# Altium schematic sheet MA_Interface,RevA,01.SchDoc
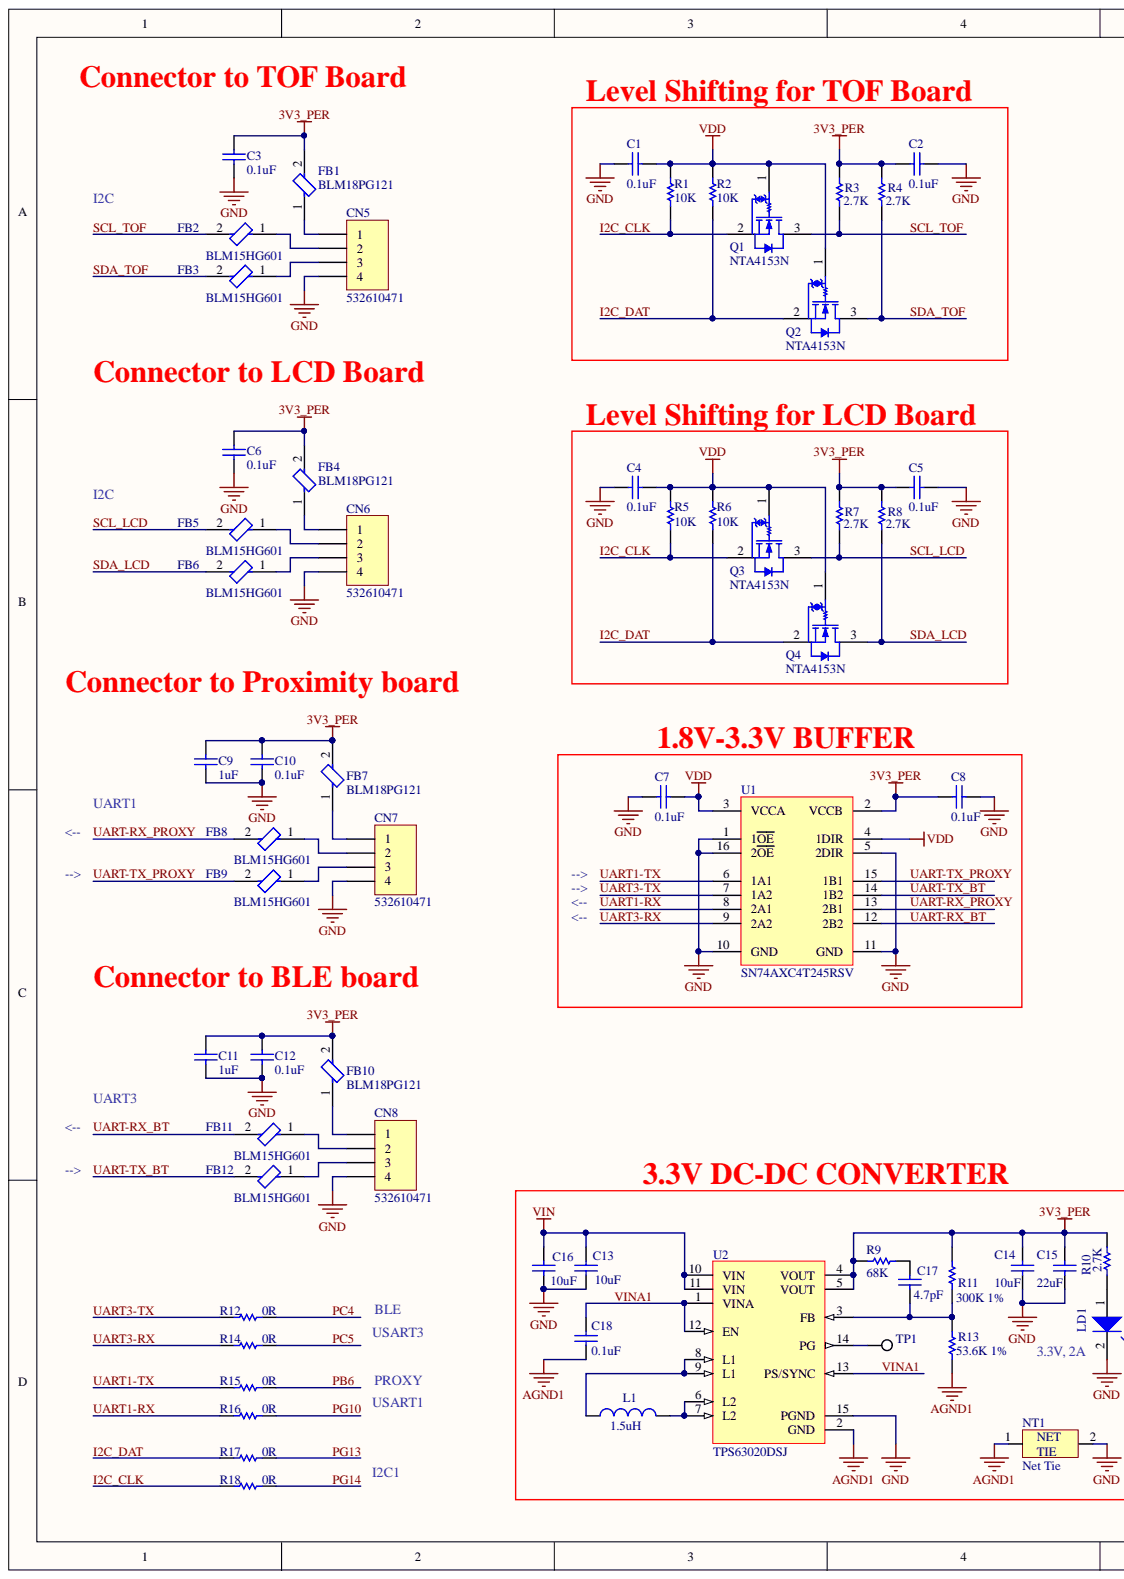
[diagram p0: MA_Interface,RevA,01.SchDoc, left]
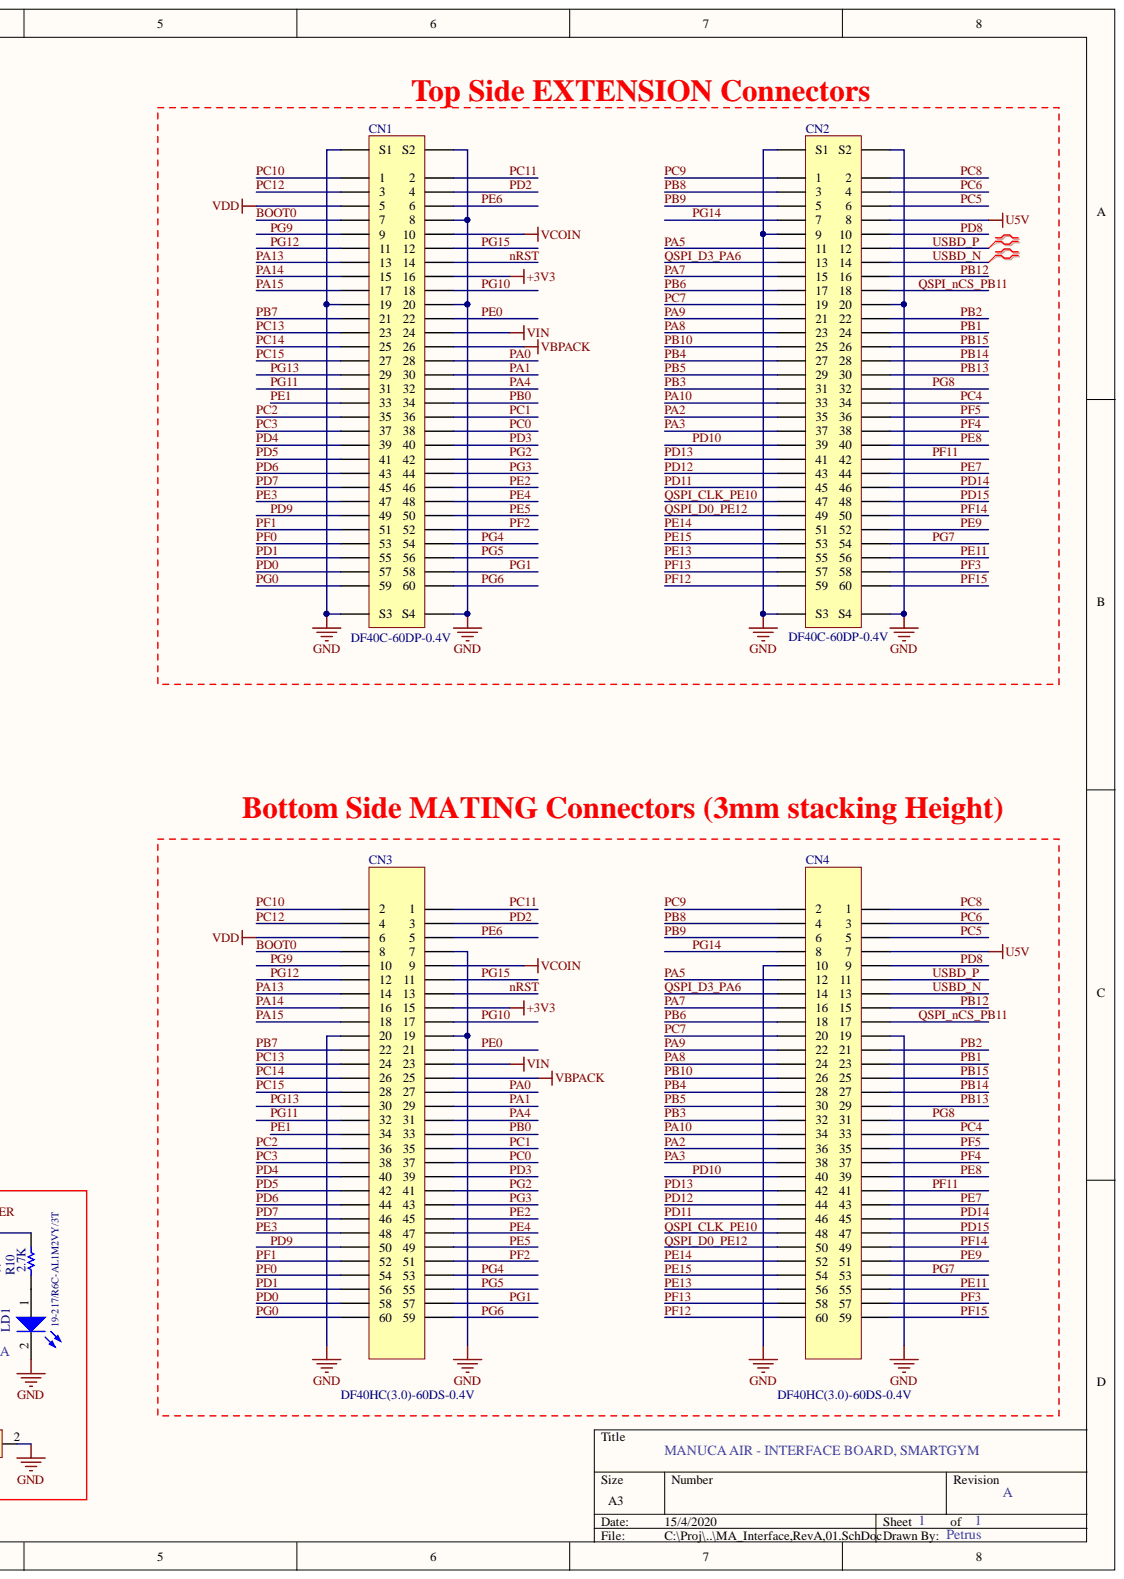
[diagram p1: MA_Interface,RevA,01.SchDoc, right]

## Parts
C1  0.1uF  [0402]
C2  0.1uF  [0402]
C3  0.1uF  [0402]
C4  0.1uF  [0402]
C5  0.1uF  [0402]
C6  0.1uF  [0402]
C7  0.1uF  [0402]
C8  0.1uF  [0402]
C9  1uF  [0603]
C10  0.1uF  [0402]
C11  1uF  [0603]
C12  0.1uF  [0402]
C13  10uF  [0805]
C14  10uF  [0805]
C15  22uF  [1210]
C16  10uF  [0805]
C17  4.7pF  [0402]
C18  0.1uF  [0402]
CN1  DF40C-60DP-0.4V  [DF40C-60DP-0.4V(51)]
CN2  DF40C-60DP-0.4V  [DF40C-60DP-0.4V(51)]
CN3  DF40HC(3.0)-60DS-0.4V  [DF40HC-(3.0)-60DS-04V]
CN4  DF40HC(3.0)-60DS-0.4V  [DF40HC-(3.0)-60DS-04V]
CN5  532610471  [53261-0471]
CN6  532610471  [53261-0471]
CN7  532610471  [53261-0471]
CN8  532610471  [53261-0471]
FB1  BLM18PG121  [0603]
FB2  BLM15HG601  [0402]
FB3  BLM15HG601  [0402]
FB4  BLM18PG121  [0603]
FB5  BLM15HG601  [0402]
FB6  BLM15HG601  [0402]
FB7  BLM18PG121  [0603]
FB8  BLM15HG601  [0402]
FB9  BLM15HG601  [0402]
FB10  BLM18PG121  [0603]
FB11  BLM15HG601  [0402]
FB12  BLM15HG601  [0402]
L1  1.5uH  [IHLP2525CZ]
LD1  19-217/R6C-AL1M2VY/3T  [0603_LED]
NT1  Net Tie  [NT_TPS63020]
Q1  NTA4153N  [SC-75-3]
Q2  NTA4153N  [SC-75-3]
Q3  NTA4153N  [SC-75-3]
Q4  NTA4153N  [SC-75-3]
R1  10K  [0402]
R2  10K  [0402]
R3  2.7K  [0402]
R4  2.7K  [0402]
R5  10K  [0402]
R6  10K  [0402]
R7  2.7K  [0402]
R8  2.7K  [0402]
R9  68K  [0402]
R10  2.7K  [0402]
R11  300K 1%  [0402]
R12  0R  [0402]
R13  53.6K 1%  [0402]
R14  0R  [0402]
R15  0R  [0402]
R16  0R  [0402]
R17  0R  [0402]
R18  0R  [0402]
TP1  5002  [TP100]  (value not drawn)
U1  SN74AXC4T245RSV  [UQFN16]
U2  TPS63020DSJ  [R-PVSON50P300W400LX100-14]

## Nets
3V3_PER: C2.1 C3.1 C5.1 C6.1 C8.1 C9.1 C10.1 C11.1 C12.1 C14.2 C15.2 FB1.2 FB4.2 FB7.2 FB10.2 R3.2 R4.2 R7.2 R8.2 R9.2 R10.2 R11.2 U1.2(VCCB) U2.4(VOUT) U2.5(VOUT)
+3V3: CN1.16 CN3.15
AGND1: C18.1 NT1.1 R13.1 U2.2(GND)
GND: C1.1 C2.2 C3.2 C4.1 C5.2 C6.2 C7.1 C8.2 C9.2 C10.2 C11.2 C12.2 C13.1 C14.1 C15.1 C16.1 CN1.8 CN1.19 CN1.20 CN1.S1 CN1.S2 CN1.S3 CN1.S4 CN2.9 CN2.20 CN2.S1 CN2.S2 CN2.S3 CN2.S4 CN3.7 CN3.19 CN3.20 CN4.10 CN4.19 CN5.4 CN6.4 CN7.4 CN8.4 LD1.2 NT1.2 U1.1(1OE) U1.5(2DIR) U1.10(GND) U1.11(GND) U1.16(2OE) U2.15(PGND)
U5V: CN2.8 CN4.7
VBPACK: CN1.26 CN3.25
VCOIN: CN1.10 CN3.9
VDD: C1.2 C4.2 C7.2 CN1.5 CN3.6 Q1.1 Q2.1 Q3.1 Q4.1 R1.2 R2.2 R5.2 R6.2 U1.3(VCCA) U1.4(1DIR)
VIN: C13.2 C16.2 CN1.24 CN3.23 U2.10(VIN) U2.11(VIN)
BOOT0: CN1.7 CN3.8
I2C_CLK: Q1.2 Q3.2 R1.1 R5.1 R18.2
I2C_DAT: Q2.2 Q4.2 R2.1 R6.1 R17.2
nRST: CN1.14 CN3.13
PA0: CN1.28 CN3.27
PA1: CN1.30 CN3.29
PA2: CN2.35 CN4.36
PA3: CN2.37 CN4.38
PA4: CN1.32 CN3.31
PA5: CN2.11 CN4.12
PA7: CN2.15 CN4.16
PA8: CN2.23 CN4.24
PA9: CN2.21 CN4.22
PA10: CN2.33 CN4.34
PA13: CN1.13 CN3.14
PA14: CN1.15 CN3.16
PA15: CN1.17 CN3.18
PB0: CN1.34 CN3.33
PB1: CN2.24 CN4.23
PB2: CN2.22 CN4.21
PB3: CN2.31 CN4.32
PB4: CN2.27 CN4.28
PB5: CN2.29 CN4.30
PB6: CN2.17 CN4.18 R15.1
PB7: CN1.21 CN3.22
PB8: CN2.3 CN4.4
PB9: CN2.5 CN4.6
PB10: CN2.25 CN4.26
PB12: CN2.16 CN4.15
PB13: CN2.30 CN4.29
PB14: CN2.28 CN4.27
PB15: CN2.26 CN4.25
PC0: CN1.38 CN3.37
PC1: CN1.36 CN3.35
PC2: CN1.35 CN3.36
PC3: CN1.37 CN3.38
PC4: CN2.34 CN4.33 R12.1
PC5: CN2.6 CN4.5 R14.1
PC6: CN2.4 CN4.3
PC7: CN2.19 CN4.20
PC8: CN2.2 CN4.1
PC9: CN2.1 CN4.2
PC10: CN1.1 CN3.2
PC11: CN1.2 CN3.1
PC12: CN1.3 CN3.4
PC13: CN1.23 CN3.24
PC14: CN1.25 CN3.26
PC15: CN1.27 CN3.28
PD0: CN1.57 CN3.58
PD1: CN1.55 CN3.56
PD2: CN1.4 CN3.3
PD3: CN1.40 CN3.39
PD4: CN1.39 CN3.40
PD5: CN1.41 CN3.42
PD6: CN1.43 CN3.44
PD7: CN1.45 CN3.46
PD8: CN2.10 CN4.9
PD9: CN1.49 CN3.50
PD10: CN2.39 CN4.40
PD11: CN2.45 CN4.46
PD12: CN2.43 CN4.44
PD13: CN2.41 CN4.42
PD14: CN2.46 CN4.45
PD15: CN2.48 CN4.47
PE0: CN1.22 CN3.21
PE1: CN1.33 CN3.34
PE2: CN1.46 CN3.45
PE3: CN1.47 CN3.48
PE4: CN1.48 CN3.47
PE5: CN1.50 CN3.49
PE6: CN1.6 CN3.5
PE7: CN2.44 CN4.43
PE8: CN2.40 CN4.39
PE9: CN2.52 CN4.51
PE11: CN2.56 CN4.55
PE13: CN2.55 CN4.56
PE14: CN2.51 CN4.52
PE15: CN2.53 CN4.54
PF0: CN1.53 CN3.54
PF1: CN1.51 CN3.52
PF2: CN1.52 CN3.51
PF3: CN2.58 CN4.57
PF4: CN2.38 CN4.37
PF5: CN2.36 CN4.35
PF11: CN2.42 CN4.41
PF12: CN2.59 CN4.60
PF13: CN2.57 CN4.58
PF14: CN2.50 CN4.49
PF15: CN2.60 CN4.59
PG0: CN1.59 CN3.60
PG1: CN1.58 CN3.57
PG2: CN1.42 CN3.41
PG3: CN1.44 CN3.43
PG4: CN1.54 CN3.53
PG5: CN1.56 CN3.55
PG6: CN1.60 CN3.59
PG7: CN2.54 CN4.53
PG8: CN2.32 CN4.31
PG9: CN1.9 CN3.10
PG10: CN1.18 CN3.17 R16.1
PG11: CN1.31 CN3.32
PG12: CN1.11 CN3.12
PG13: CN1.29 CN3.30 R17.1
PG14: CN2.7 CN4.8 R18.1
PG15: CN1.12 CN3.11
QSPI_CLK_PE10: CN2.47 CN4.48
QSPI_D0_PE12: CN2.49 CN4.50
QSPI_D3_PA6: CN2.13 CN4.14
QSPI_nCS_PB11: CN2.18 CN4.17
SCL_LCD: FB5.2 Q3.3 R7.1
SCL_TOF: FB2.2 Q1.3 R3.1
SDA_LCD: FB6.2 Q4.3 R8.1
SDA_TOF: FB3.2 Q2.3 R4.1
UART1-RX: R16.2 U1.8(2A1)
UART1-TX: R15.2 U1.6(1A1)
UART3-RX: R14.2 U1.9(2A2)
UART3-TX: R12.2 U1.7(1A2)
UART-RX_BT: FB11.2 U1.12(2B2)
UART-RX_PROXY: FB8.2 U1.13(2B1)
UART-TX_BT: FB12.2 U1.14(1B2)
UART-TX_PROXY: FB9.2 U1.15(1B1)
USBD_N: CN2.14 CN4.13
USBD_P: CN2.12 CN4.11
VINA1: C18.2 U2.1(VINA) U2.12(EN) U2.13(PS/SYNC)
NetC17_1: C17.1 R11.1 R13.2 U2.3(FB)
NetC17_2: C17.2 R9.1
NetCN5_1: CN5.1 FB1.1
NetCN5_2: CN5.2 FB2.1
NetCN5_3: CN5.3 FB3.1
NetCN6_1: CN6.1 FB4.1
NetCN6_2: CN6.2 FB5.1
NetCN6_3: CN6.3 FB6.1
NetCN7_1: CN7.1 FB7.1
NetCN7_2: CN7.2 FB8.1
NetCN7_3: CN7.3 FB9.1
NetCN8_1: CN8.1 FB10.1
NetCN8_2: CN8.2 FB11.1
NetCN8_3: CN8.3 FB12.1
NetL1_1: L1.1 U2.8(L1) U2.9(L1)
NetL1_2: L1.2 U2.6(L2) U2.7(L2)
NetLD1_1: LD1.1 R10.1
NetTP1_1: TP1.1 U2.14(PG)

## Library data of the parts
C1-C8 C10 C12 C18 = Capacitor_ST: Capacitor MLCC X7R 0.1uF 25V 0402; Manufacturer: Yageo; PartNumber: CC0402KRX7R8BB104; Component Kind: Standard; Signal Integrity: Cap; Simulation: CAP; Library Name: SensorPOD_R0.SCHLIB
C9 C11 = Capacitor_ST: Capacitor MLCC X7R 1uF 10V 0603; Manufacturer: Yageo; PartNumber: CC0603KRX7R6BB105; Component Kind: Standard; Signal Integrity: Cap; Simulation: CAP; Library Name: SensorPOD_R0.SCHLIB
C13 C14 C16 = Capacitor_ST: Capacitor MLCC X7R 10uF 10V 0805; Manufacturer: Murata; PartNumber: GRM21BR71A106KA73L; Component Kind: Standard; Signal Integrity: Cap; Simulation: CAP; Library Name: SensorPOD_R0.SCHLIB
C15 = Capacitor_ST: Capacitor MLCC X7R 22uF 10V 1210; Manufacturer: Murata; PartNumber: GRM32ER71A226KE20L; Component Kind: Standard; Signal Integrity: Cap; Simulation: CAP; Value: 22uF; Library Name: SensorPOD_R0.SCHLIB
C17 = Capacitor_ST: Capacitor MLCC NP0 4.7pF 50V 0402; Manufacturer: Yageo; PartNumber: CC0402BRNPO9BN4R7; Component Kind: Standard; Signal Integrity: Cap; Simulation: CAP; Value: 4.7pF; Library Name: SensorPOD_R0.SCHLIB
CN1 CN2 = DF40C-60DP: Connector, DF40 Series Header 60 pin no enforcing metal fitting; Manufacturer: Hirose; Component Kind: Standard; PartNumber: DF40C-60DP-0.4V(51); Library Name: SensorPOD_RevC.SCHLIB
CN3 CN4 = DF40HC(3.0)-60DS: Connector, Hirose DF40 series receptacle 60 pin 3mm stacking height no enforcing metal fitting; PartNumber: DF40HC(3.0)-60DS-0.4V(51); Component Kind: Standard; Manufacturer: Hirose; Library Name: SensorPOD_RevC.SCHLIB
CN5-CN8 = Header 4: Connector 1.25mm Pitch PicoBlade WTB Header, SMD R/A, with Friction Lock, 4 Circuits; Manufacturer: Molex; Component Kind: Standard; PartNumber: 532610471; Signal Integrity: Connector; Library Name: SensorPOD_RevC.SCHLIB
FB1 FB4 FB7 FB10 = Ferrite Bead: Ferrite Beads Multi-Layer 120Ohm 25% 100MHz 2A 50mOhm DCR 0603; Manufacturer: Murata; PartNumber: BLM18PG121SN1D; Component Kind: Standard; ComponentLink1Description: Upverter; ComponentLink1URL: https://upverter.com/upn/revision/9e0a6ae32f230a59/; ComponentLink2Description: Datasheet; ComponentLink2URL: http://www.murata.com/en-eu/products/productdata/8796738650142/ENFA0003.pdf?1496719828000; Library Name: SensorPOD_R02.SCHLIB
FB2 FB3 FB5 FB6 FB8 FB9 FB11 FB12 = Ferrite Bead: Ferrite Beads Multi-Layer 600 Ohm 25% 100MHz 300mA 700mOhm DCR 0402; Manufacturer: Murata; PartNumber: BLM15HG601SN1D; Component Kind: Standard; ComponentLink1Description: Upverter; ComponentLink1URL: https://upverter.com/upn/revision/9e0a6ae32f230a59/; ComponentLink2Description: Datasheet; ComponentLink2URL: http://www.murata.com/en-eu/products/productdata/8796738650142/ENFA0003.pdf?1496719828000; Library Name: SensorPOD_R02.SCHLIB
L1 = Inductor: Inductor Power Shielded Wirewound 1.5uH Powdered Iron 9A 15mOhm DCR; Manufacturer: Vishay; PartNumber: IHLP2525CZER1R5M01; Component Kind: Standard; Library Name: Miscellaneous Devices.IntLib; Signal Integrity: Inductor; Simulation: INDUCTOR
LD1 = LED2: LED SMD Top View Uni-Color Brilliant Red 624nm 0603; Manufacturer: Everlight; Component Kind: Standard; Library Name: Miscellaneous Devices.IntLib; PartNumber: 19-217/R6C-AL1M2VY/3T
NT1 = Net Tie: Net Tie, for connecting Two/more different Nets; Component Kind: Net Tie; Library Name: SensorPOD_R0.SCHLIB
Q1-Q4 = NTA4153N: MOSFET, 20 V, 915 mA, Single N-Channel with ESD Protection, 3-Pin SC75; Manufacturer: Onsemi; PartNumber: NTA4153NT1G; Component Kind: Standard; ComponentLink1Description: Manufacturer URL; ComponentLink1URL: http://www.onsemi.com/; ComponentLink2Description: Datasheet; ComponentLink2URL: http://www.onsemi.cn/pub_link/Collateral/NTA4153N-D.PDF; ComponentLink3Description: Package Specification; ComponentLink3URL: http://www.onsemi.com/pub_link/Collateral/463-01.PDF; Library Name: SensorPOD_RevC.SCHLIB
R1 R2 R5 R6 = Res1: Resistor Thick Film 0402 10K Ohm 5%; Manufacturer: KOA Speer; PartNumber: RK73B1ETTP103J; Component Kind: Standard; Library Name: Miscellaneous Devices.IntLib; Signal Integrity: Res; Simulation: RESISTOR
R3 R4 R7 R8 = Res1: Resistor, Thick Film 0402 2.7K Ohm 5%; Manufacturer: Yageo; PartNumber: RC0402JR-072K7L; Component Kind: Standard; Library Name: Miscellaneous Devices.IntLib; Signal Integrity: Res; Simulation: RESISTOR; Value: 2.7K
R9 = Res1: Resistor Thick Film 0402 68K Ohm 5%; Manufacturer: KOA Speer; PartNumber: RK73B1ETTP683J; Component Kind: Standard; Library Name: SensorPOD_R0.SCHLIB
R10 = Res1: Resistor Thick Film 0402 2.7K Ohm 5%; Manufacturer: KOA Speer; PartNumber: RK73B1ETTP272J; Component Kind: Standard; Library Name: Miscellaneous Devices.IntLib; Signal Integrity: Res; Simulation: RESISTOR
R11 = Res1: Resistor Thick Film 0402 300K Ohm 1%; Manufacturer: KOA Speer; PartNumber: RK73H1ETTP3003F; Component Kind: Standard; Library Name: SensorPOD_R0.SCHLIB
R12 R14-R18 = Res1: Resistor Thick Film 0402 0 ohm jumper; Manufacturer: KOA Speer; PartNumber: RK73Z1ETTP; Component Kind: Standard; Library Name: SensorPOD_R0.SCHLIB
R13 = Res1: Resistor Thick Film 0402 53.6K Ohm 1%; Manufacturer: KOA Speer; PartNumber: RK73H1ETTP5362F; Component Kind: Standard; Library Name: SensorPOD_R0.SCHLIB
TP1 = 5002: Test Point, Miniature, White, TH; Manufacturer: Keystone; CompType: Test Point Loop; ContactFinish: Silver; Green_Lifecycle: Active; Green_REACh: Passed; Color: White; Component Kind: Standard; ComponentLink1Description: Datasheet URL; ComponentLink1URL: http://www.keyelco.com/userAssets/file/M60-2p60.pdf; Green_EU RoHS: Passed; Green_EU RoHS-2: Passed; LatestRevisionDate: 2017-05-11; Library Name: Connectors_Keystone.IntLib; MountType: TH; PackageReference: White Miniature Testpoint; PartNumber: 5002; RoHS: Y; Supplier 1: Digi-Key; Supplier 2: Newark; Supplier 3: Mouser; Supplier Part Number 1: 36-5002-ND; Supplier Part Number 2: 82H9632; Supplier Part Number 3: 534-5002; SymbolVersion: 2008-04-04; Publisher: Texas Instruments
U1 = SN74AXC4T245RSV: 4-Bit Bus Transceiver with Configurable Voltage Translation and Tri-State Outputs 16-Pin UQFN; Manufacturer: TI; Component Kind: Standard; PartNumber: SN74AXC4T245RSV; Library Name: SensorPOD_RevC.SCHLIB
U2 = TPS63020DSJR: High Efficiency Single Inductor Buck-Boost Converter with 4A Switch 14-VSON; Manufacturer: TI; Component Kind: Standard; PartNumber: TPS63020DSJ; Library Name: SensorPOD_RevC.SCHLIB
